# Altium schematic sheet PSU.SchDoc
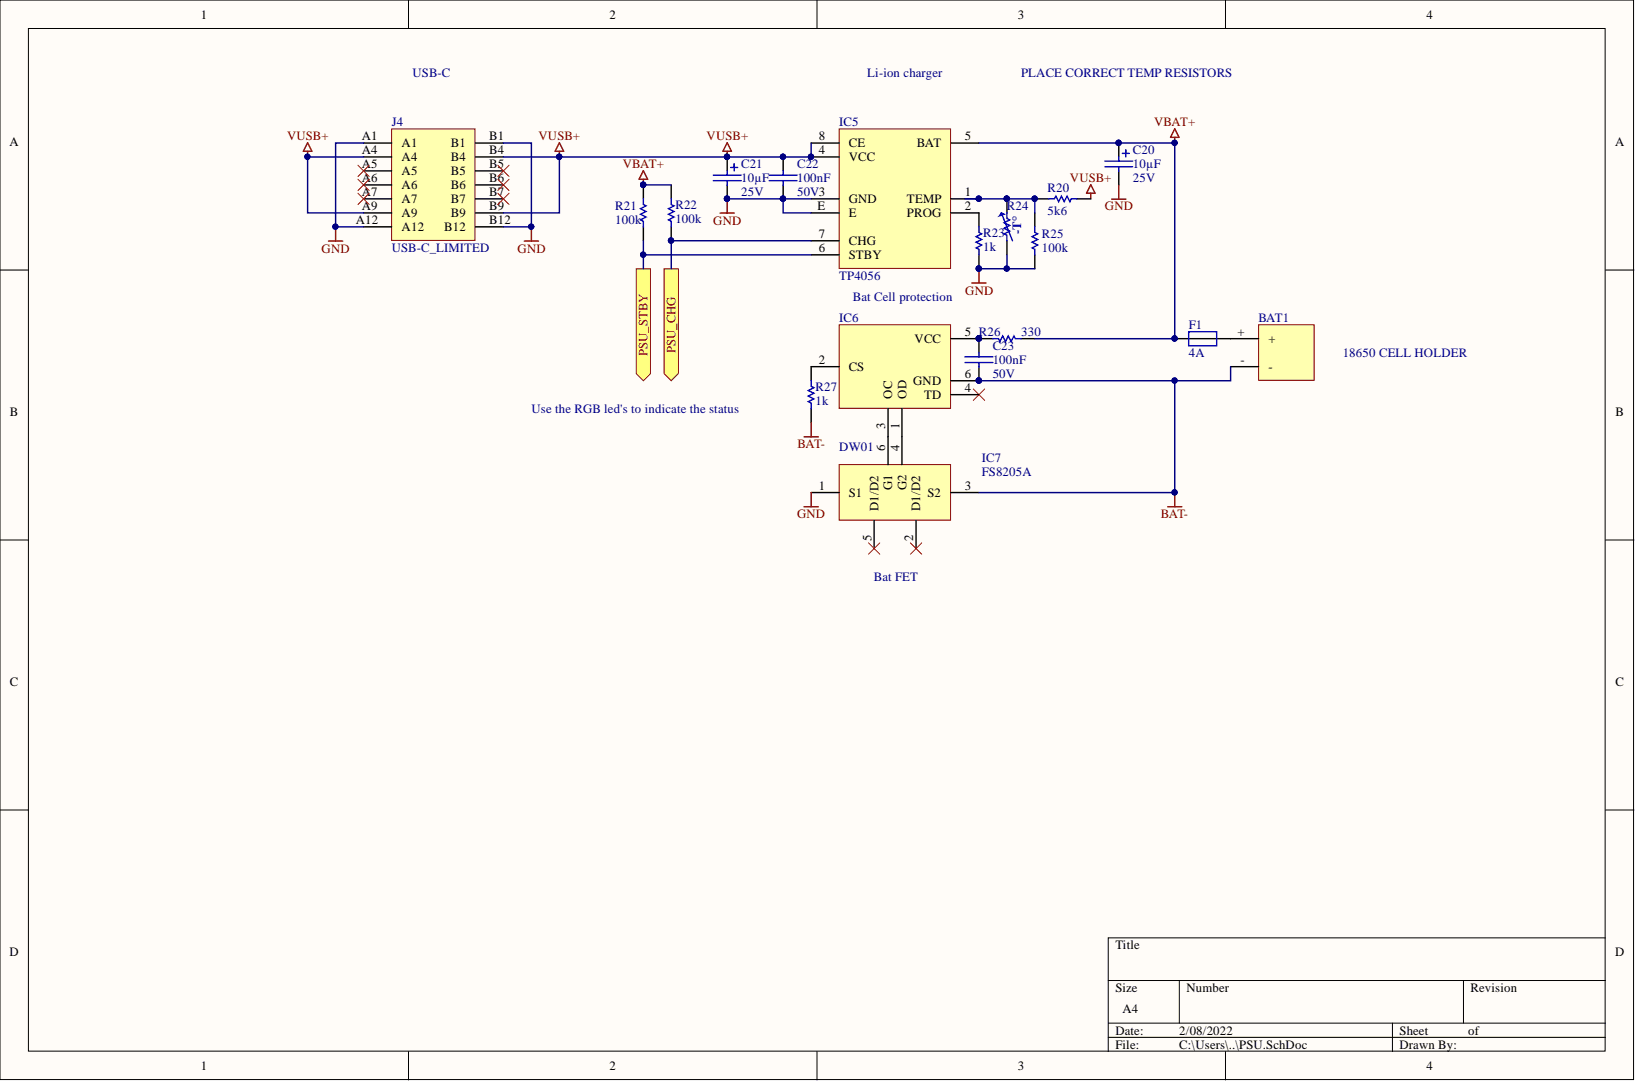
[diagram p0: PSU.SchDoc]

## Parts
BAT1  18650 CELL HOLDER  [T353]
C20  value 10µF  voltage: 25V  [CD6.3H12TH]  (hidden comment: cap-pol)
C21  value 10µF  voltage: 25V  [CD6.3H12TH]  (hidden comment: cap-pol)
C22  value 100nF  voltage: 50V  [TH5.08]  (hidden comment: cap_non_pol)
C23  value 100nF  voltage: 50V  [TH5.08]  (hidden comment: cap_non_pol)
F1  value 4A  [F0603]  (hidden comment: fuse)
IC5  TP4056
IC6  DW01  [SOT-23-6]
IC7  FS8205A  [SOT-23-6]
J4  USB-C_LIMITED  [GT-USB-7037]
R20  value 5k6  [TH6.3]  (hidden comment: resistor)
R21  value 100k  [TH6.3]  (hidden comment: resistor)
R22  value 100k  [TH6.3]  (hidden comment: resistor)
R23  value 1k  [TH6.3]  (hidden comment: resistor)
R24  thermistor  [TH6.3]  (value not drawn)
R25  value 100k  [TH6.3]  (hidden comment: resistor)
R26  value 330  [TH6.3]  (hidden comment: resistor)
R27  value 1k  [TH6.3]  (hidden comment: resistor)

## Ports
PSU_CHG, PSU_STBY

## Nets
BAT-: BAT1.- C23.2 IC6.6(GND) IC7.3(S2) R27.2
GND: C20.- C21.- C22.2 IC5.3(GND) IC5.E IC7.1(S1) J4.A1 J4.A12 J4.B1 J4.B12 R23.2 R24.1 R25.1
VBAT+: C20.+ F1.1 IC5.5(BAT) R21.2 R22.1 R26.2
VUSB+: C21.+ C22.1 IC5.4(VCC) IC5.8(CE) J4.A4 J4.A9 J4.B4 J4.B9 R20.2
PSU_CHG: IC5.7(CHG) R22.2 ; port PSU_CHG
PSU_STBY: IC5.6(STBY) R21.1 ; port PSU_STBY
NetBAT1_+: BAT1.+ F1.2
NetC23_1: C23.1 IC6.5(VCC) R26.1
NetIC5_1: IC5.1(TEMP) R20.1 R24.2 R25.2
NetIC5_2: IC5.2(PROG) R23.1
NetIC6_1: IC6.1(OD) IC7.4(G2)
NetIC6_2: IC6.2(CS) R27.1
NetIC6_3: IC6.3(OC) IC7.6(G1)

Not connected: IC6 4(TD); IC7 2(D1/D2) 5(D1/D2); J4 A5 A6 A7 B5 B6 B7

## Library data of the parts
BAT1 = 12: 18650 single cell Battery holder; manufacturer: GTIWUNG; Database Table Name: others$; Component Kind: Standard; id: 12; Library Name: js-altium-library.DbLib; manufacturer_ref: T353; package: T353; price: 0,99; status: active; supplier_1: amazon; supplier_1_partnumber: B08CY56M98; type: Battery holder
C20 C21 = 4: CAP ALUM 10UF 20% 25V TH; manufacturer: Yunxing; manufacturer_ref: ECF1KM100E12OTPO; Database Table Name: capacitor_electrolytic$; dielectric: Aluminium; Component Kind: Standard; id: 4; Library Name: js-altium-library.DbLib; package: d6,3h12TH; price: 0,0229; status: active; supplier_1: lcsc; supplier_1_partnumber: C2841587; tolerance: 20%
C22 C23 = 37: CAP CER TH 100nF X7R 50V; manufacturer: FH; manufacturer_ref: C2761753; Database Table Name: capacitor_ceramic$; tolerance: 10%; Component Kind: Standard; dielectric: X7R; id: 37; Library Name: js-altium-library.DbLib; package: TH5.08; price: 0,0237; status: active; supplier_1: lcsc; supplier_1_partnumber: C385200
F1 = 8: FUSE BOARD MOUNT 4A 32VDC 0603; manufacturer: Vishay Beyschlag/Draloric/BC Components; manufacturer_ref: MFU0603FF04000P500; Database Table Name: fuse$; response_time: FAST; Component Kind: Standard; id: 8; Library Name: js-altium-library.DbLib; package: 0603; price: 0,22; status: active; supplier_1: digikey; supplier_1_partnumber: 749-MFU0603FF04000P500CT-ND
IC6 = 59: IC  Battery Management IC; manufacturer: Fortune Semicon; manufacturer_ref: DW01+G; Database Table Name: ic$; Component Kind: Standard; id: 59; Library Name: js-altium-library.DbLib; package: SOT-23-6; price: 0,153; status: active; supplier_1: lcsc; supplier_1_partnumber: C14213; type: PMIC (Battery Management)
IC7 = 60: IC  MOSFET Array 3A; manufacturer: TECH PUBLIC; Database Table Name: ic$; Component Kind: Standard; id: 60; Library Name: js-altium-library.DbLib; manufacturer_ref: FS8205A; package: SOT-23-6; price: 0,06; status: active; supplier_1: lcsc; supplier_1_partnumber: C2830320; type: MOSFET ARRAY
J4 = 30: USB TYPE C , RECEPTACLE, R/A; manufacturer: G-Switch; Database Table Name: connector$; nbr_positions_total: 14; Component Kind: Standard; id: 30; Library Name: js-altium-library.DbLib; manufacturer_ref: GT-USB-7037; package: USB-C_LIMITED; price: 0,4797; status: active; supplier_1: lcsc; supplier_1_partnumber: C2762981
R20 = 64: RES TH 4k12 OHM 1% 1/4W; manufacturer: UNI-ROYAL(Uniroyal Elec); manufacturer_ref: MFR0W4F5601A50; Database Table Name: resistor_general$; tolerance: 0,01; Component Kind: Standard; id: 64; Library Name: js-altium-library.DbLib; package: TH6,3; power: 1/4W; price: 0,02; status: active; supplier_1: lcsc; supplier_1_partnumber: C58603
R21 R22 R25 = 56: RES TH 100k OHM 5% 1/4W; manufacturer: VO; manufacturer_ref: CR1/4W-100K±5%-RT52; Database Table Name: resistor_general$; Component Kind: Standard; id: 56; Library Name: js-altium-library.DbLib; package: TH6.3; power: 1/4W; price: 0,0038; status: active; supplier_1: lcsc; supplier_1_partnumber: C2894520; tolerance: 5%
R23 R27 = 57: RES TH 1k OHM 5% 1/4W; manufacturer: VO; manufacturer_ref: CR1/4W-1K±5%-OT52; Database Table Name: resistor_general$; Component Kind: Standard; id: 57; Library Name: js-altium-library.DbLib; package: TH6.3; power: 1/4W; price: 0,0038; status: active; supplier_1: lcsc; supplier_1_partnumber: C2894570; tolerance: 5%
R24 = 4: THERMISTOR NTC 10KOHM 3435K BEAD; manufacturer: Nanjing Shiheng Elec; manufacturer_ref: MF58 103J3435; Database Table Name: resistor_others$; power: 1/20W; tolerance: 5%; value: 10k; Component Kind: Standard; id: 4; Library Name: js-altium-library.DbLib; package: TH6.3; price: 0,0059; status: active; supplier_1: lcsc; supplier_1_partnumber: C123389; type: NTC_THERMISTOR
R26 = 58: RES TH 330 OHM 5% 1/4W; manufacturer: VO; manufacturer_ref: CR1/4W-330Ω±5%-OT52; Database Table Name: resistor_general$; Component Kind: Standard; id: 58; Library Name: js-altium-library.DbLib; package: TH6.3; power: 1/4W; price: 0,0041; status: active; supplier_1: lcsc; supplier_1_partnumber: C2894590; tolerance: 5%
IC5 = TP4056: Component Kind: Standard; Library Name: ic.SchLib
